# Altium schematic sheet OnePhase_MainPower.SchDoc
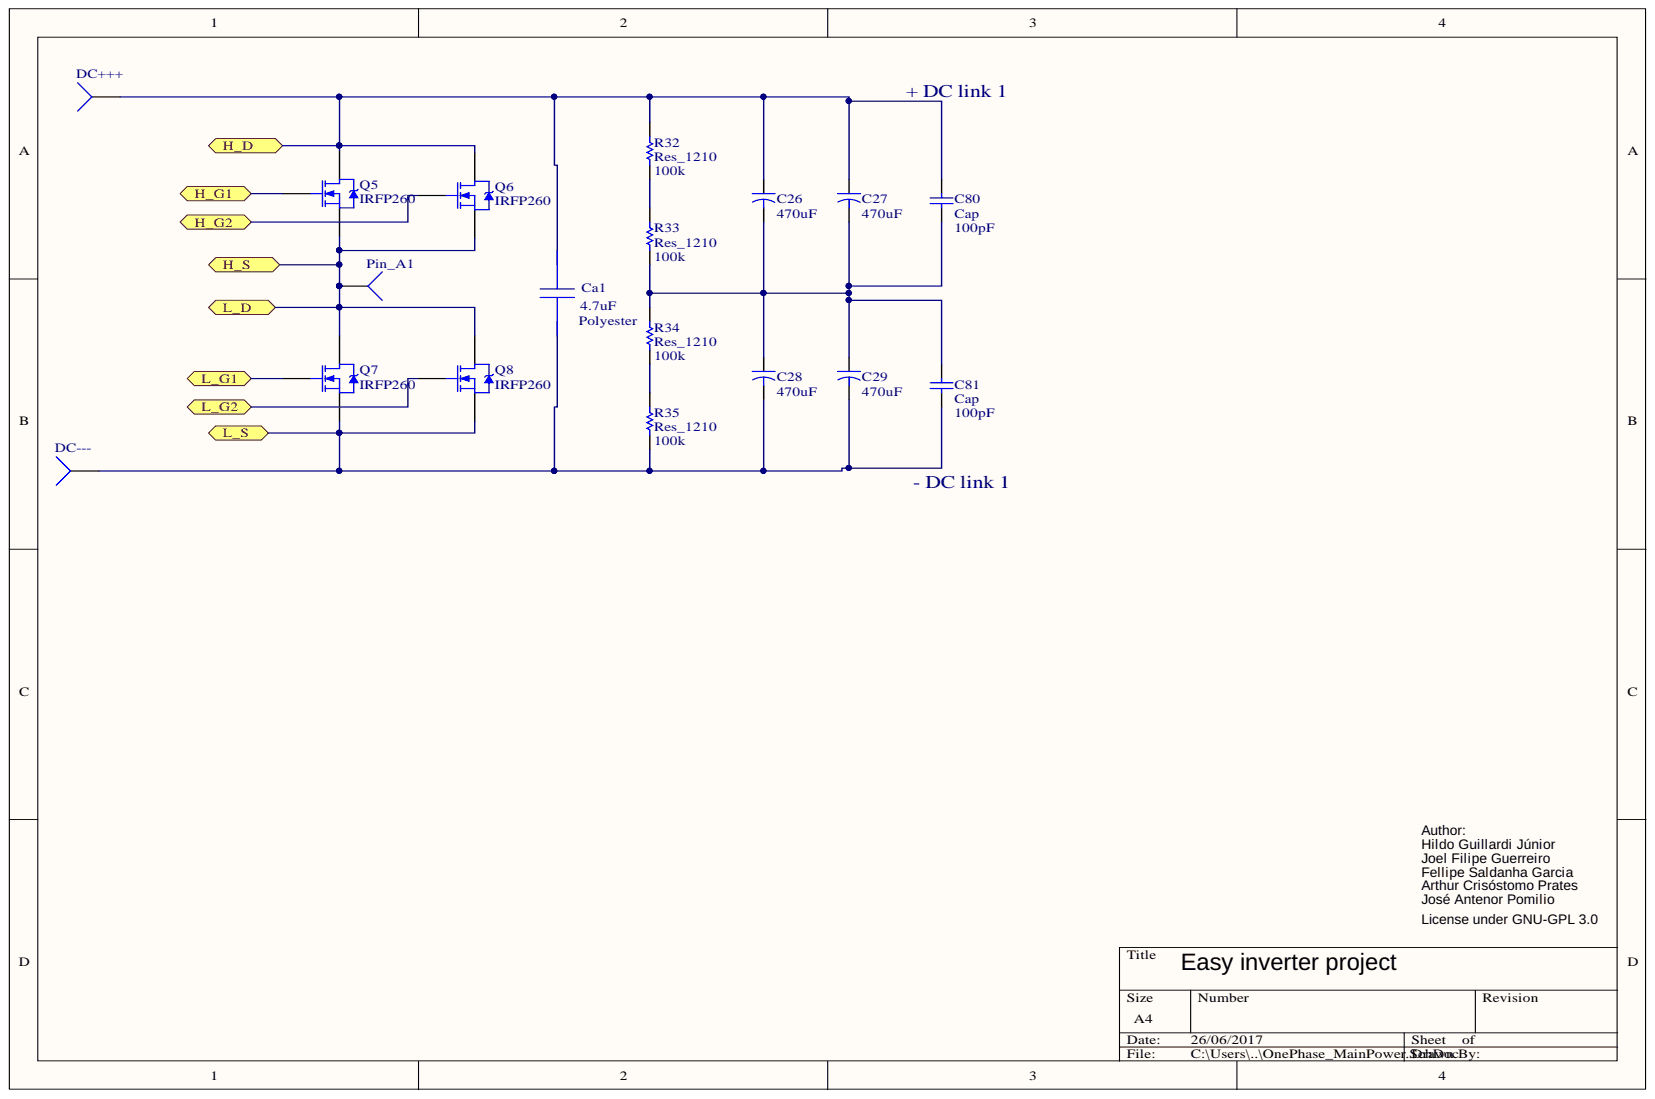
[diagram p0: OnePhase_MainPower.SchDoc]

## Parts
C26  value 470uF  [Capacitor Eletrolitico - duplicate]  (hidden comment: Cap2)
C27  value 470uF  [Capacitor Eletrolitico - duplicate]  (hidden comment: Cap2)
C28  value 470uF  [Capacitor Eletrolitico - duplicate]  (hidden comment: Cap2)
C29  value 470uF  [Capacitor Eletrolitico - duplicate]  (hidden comment: Cap2)
C80  Cap  value 100pF  [Capacitor_Pol_15x6mm]
C81  Cap  value 100pF  [Capacitor_Pol_15x6mm]
Ca1  Polyester  value 4.7uF  [Capacitor_Pol_2Sizes]
DC+++  Socket  [Power conector]  (value not drawn)
DC---  Socket  [Power conector]  (value not drawn)
Pin_A1  Socket  [Power conector]  (value not drawn)
Q5  IRFP260  [TO227]
Q6  IRFP260  [TO227]
Q7  IRFP260  [TO227]
Q8  IRFP260  [TO227]
R32  Res_1210  value 100k  [1210_W]
R33  Res_1210  value 100k  [1210_W]
R34  Res_1210  value 100k  [1210_W]
R35  Res_1210  value 100k  [1210_W]

## Ports
H_D, H_G1, H_G2, H_S, L_D, L_G1, L_G2, L_S

## Nets
H_D: C26.1 C27.1 C80.2 Ca1.1 DC+++.1 Q5.2 Q6.2 R32.1 ; port H_D
H_G1: Q5.1 ; port H_G1
H_G2: Q6.1 ; port H_G2
H_S: Pin_A1.1 Q5.3 Q6.3 Q7.2 Q8.2 ; port H_S, port L_D
L_G1: Q7.1 ; port L_G1
L_G2: Q8.1 ; port L_G2
L_S: C28.2 C29.2 C81.1 Ca1.2 DC---.1 Q7.3 Q8.3 R35.2 ; port L_S
NetC26_2: C26.2 C27.2 C28.1 C29.1 C80.1 C81.2 R33.2 R34.1
NetR32_2: R32.2 R33.1
NetR34_2: R34.2 R35.1

## Library data of the parts
C26-C29 = Cap2: Capacitor; Component Kind: Standard; Library Name: Miscellaneous Devices.IntLib; PackageDescription: Capacitor; 2 Leads; PackageReference: CAPR5-4X5; Published: 23-Sep-2002; Signal Integrity: Cap; Simulation: CAP; Publisher: Altium Limited
C80 C81 = Cap: Capacitor; Component Kind: Standard; LatestRevisionDate: 17-Jul-2002; LatestRevisionNote: Re-released for DXP Platform.; Library Name: Miscellaneous Devices.IntLib; PackageDescription: Radial Cap, Thru-Hole; 2 Leads; 0.3 in Pin Spacing; PackageReference: RAD-0.3; Published: 8-Jun-2000; Signal Integrity: Cap; Simulation: CAP; Publisher: Altium Limited
Ca1 = GRM21BC81E475KA12L: GRM21BC81E475KA1; Manufacturer Name: MuRata; Component Kind: Standard; Library Name: TI WEBENCH; Manufacturer Part Number: GRM21BC81E475KA12L; PackageReference: GRM21BC81E475KA12L
Q5-Q8 = IRFP260: HEXFET Power MOSFET; Note: Pin 2 is electrically connected to the Heatsink (4).; Code_JEDEC: TO-247-AC; Component Kind: Standard; ComponentLink1Description: Manufacturer Link; ComponentLink1URL: http://www.irf.com/; ComponentLink2Description: Datasheet; ComponentLink2URL: http://www.irf.com/product-info/datasheets/data/irfp260.pdf; DatasheetVersion: PD-9.755; LatestRevisionDate: 17-Jul-2002; LatestRevisionNote: Re-released for DXP Platform.; Library Name: IR Discrete MOSFET - Power.IntLib; PackageDescription: SIP with Mounting Hole; 3 Leads; Body 20 x 15.6 x 5 mm (typ); Pitch 5.45 mm; PackageReference: TO-247AC; PackageVersion: Aug-1997; Published: 8-Jun-2000; Simulation: IRFP260; Publisher: Altium Limited
R32-R35 = Res_0805: Resistor; Manufacturer: Generic Components; Class: Passive; Component Kind: Standard; Published: 08/06/2000; Signal Integrity: Res1; Simulation: RESISTOR; SubClass: Resistor; Library Name: Inversor_LCEE.SchLib; Publisher: Altium Hobart Technology Centre
DC+++ DC--- Pin_A1 = Socket: Component Kind: Standard; Description: Socket; LatestRevisionDate: 17-Jul-2002; LatestRevisionNote: Re-released for DXP Platform.; Library Name: Miscellaneous Connectors.IntLib; Signal Integrity: Connector; Publisher: Altium Limited
